# Altium schematic sheet Placa_extrusora.SchDoc(Placa_extrusora)
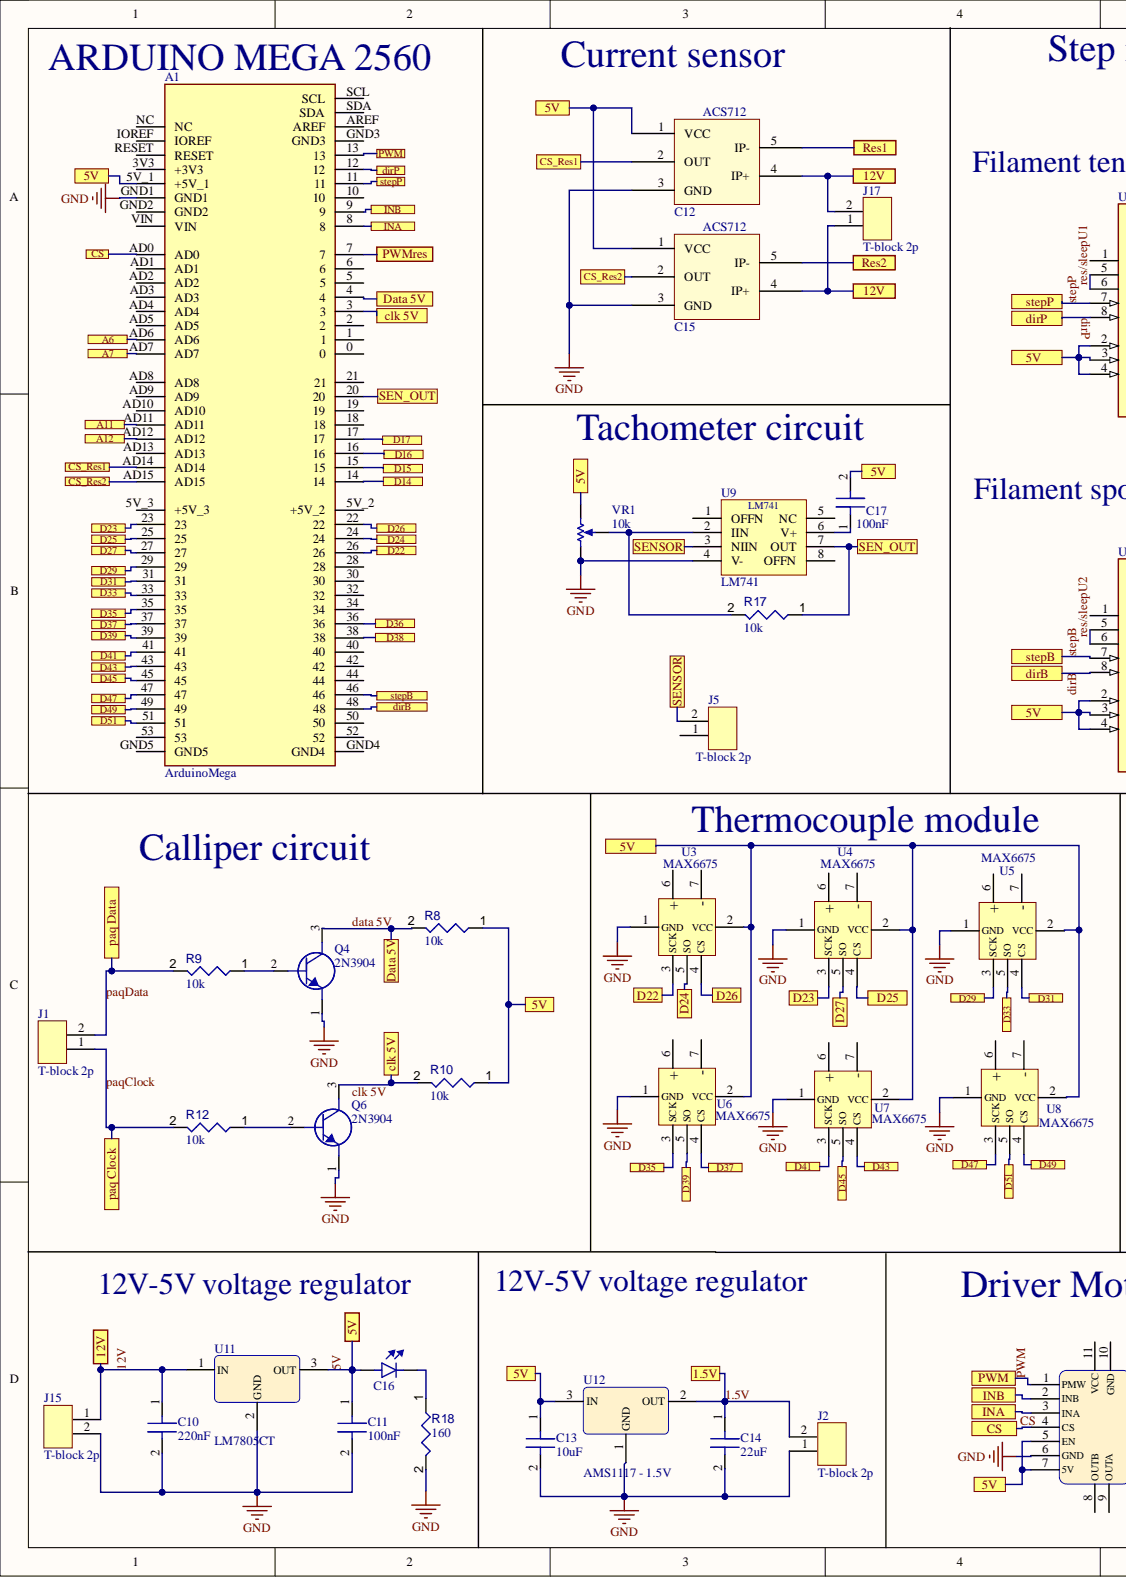
[diagram p0: Placa_extrusora.SchDoc, left]
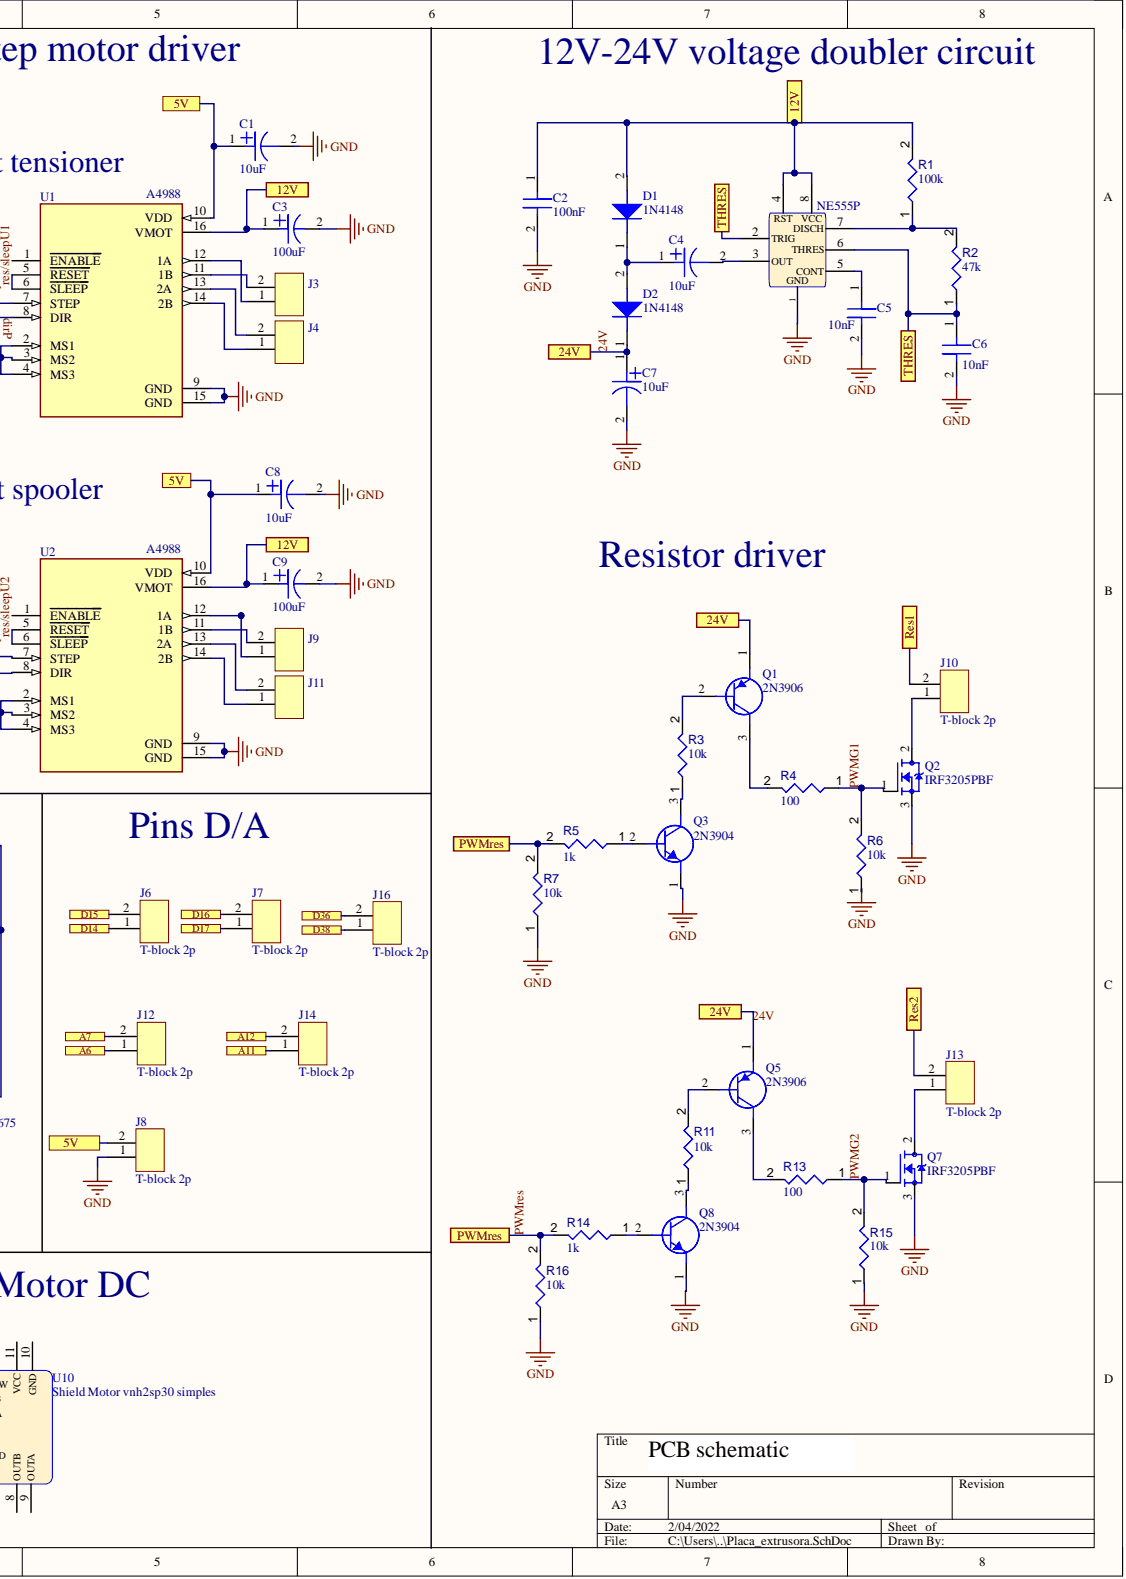
[diagram p1: Placa_extrusora.SchDoc, right]

## Parts
*  NE555P  [NE555P]
A1  ArduinoMega  [AmegaHeaders]
C1  10uF  [ElerolitcCap 35V]
C2  100nF  [CeramicCap 50V]
C3  100uF  [SMD CAP]
C4  10uF  [ElerolitcCap 35V]
C5  10nF  [CeramicCap 50V]
C6  10nF  [CeramicCap 50V]
C7  10uF  [ElerolitcCap 35V]
C8  10uF  [ElerolitcCap 35V]
C9  100uF  [SMD CAP]
C10  220nF  [CeramicCap 50V]
C11  100nF  [CeramicCap 50V]
C12  CMP-00001-1  [Current Sensor Module ACS712-Footprint-1]  (value not drawn)
C13  10uF  [CeramicCap 50V]
C14  22uF  [CeramicCap 50V]
C15  CMP-00001-1  [Current Sensor Module ACS712-Footprint-1]  (value not drawn)
C16  CMP-00006-2  [LED 5mm-Footprint-1]  (value not drawn)
C17  100nF  [CeramicCap 50V]
D1  1N4148  [1n4148 th]
D2  1N4148  [1n4148 th]
J1  T-block 2p  [T-block 2p]
J2  T-block 2p  [T-block 2p]
J3  T-block 2p  [T-block 2p]
J4  T-block 2p  [T-block 2p]
J5  T-block 2p  [T-block 2p]
J6  T-block 2p  [T-block 2p]
J7  T-block 2p  [T-block 2p]
J8  T-block 2p  [T-block 2p]
J9  T-block 2p  [T-block 2p]
J10  T-block 2p  [T-block 2p]
J11  T-block 2p  [T-block 2p]
J12  T-block 2p  [T-block 2p]
J13  T-block 2p  [T-block 2p]
J14  T-block 2p  [T-block 2p]
J15  T-block 2p  [T-block 2p]
J16  T-block 2p  [T-block 2p]
J17  T-block 2p  [T-block 2p]
Q1  2N3906  [2N3906]
Q2  IRF3205PBF  [IRF3205]
Q3  2N3904  [2N3904]
Q4  2N3904  [2N3904]
Q5  2N3906  [2N3906]
Q6  2N3904  [2N3904]
Q7  IRF3205PBF  [IRF3205]
Q8  2N3904  [2N3904]
R1  100k  [RESA60-630X240]
R2  47k  [RESA60-630X240]
R3  10k  [RESA60-630X240]
R4  100  [RESA60-630X240]
R5  1k  [RESA60-630X240]
R6  10k  [RESA60-630X240]
R7  10k  [RESA60-630X240]
R8  10k  [RESA60-630X240]
R9  10k  [RESA60-630X240]
R10  10k  [RESA60-630X240]
R11  10k  [RESA60-630X240]
R12  10k  [RESA60-630X240]
R13  100  [RESA60-630X240]
R14  1k  [RESA60-630X240]
R15  10k  [RESA60-630X240]
R16  10k  [RESA60-630X240]
R17  10k  [RESA60-630X240]
R18  value 160  [RESA60-630X240]  (hidden comment: 10k)
U1  A4988  [MODULE_A4988_STEPPER_MOTOR_DRIVER_CARRIER]
U2  A4988  [MODULE_A4988_STEPPER_MOTOR_DRIVER_CARRIER]
U3  MAX6675  [Max6675]
U4  MAX6675  [Max6675]
U5  MAX6675  [Max6675]
U6  MAX6675  [Max6675]
U7  MAX6675  [Max6675]
U8  MAX6675  [Max6675]
U9  LM741  [LM741 DIP]
U10  Shield Motor vnh2sp30 simples  [Shield monster simples VNH2SP30]
U11  LM7805CT  Output Voltage: 5V  [L78XX FAMILY]
U12  AMS1117 - 1.5V  [AMS1117]
VR1  Resistance: 10k  [BOUR-3362P]  (hidden comment: 3362P-1-103LF)

## Ports
1.5V, 5V, 12V, 24V, A6, A7, A11, A12, clk 5V, CS, CS_Res1, CS_Res2, D14, D15, D16, D17, D22, D23, D24, D25, D26, D27, D29, D31, D33, D35, D36, D37, D38, D39, D41, D43, D45, D47, D49, D51, Data 5V, dirB, dirP, INA, INB, paq Clock, paq Data, PWM, PWMres, Res1, Res2, SEN_OUT, SENSOR, stepB, stepP, THRES

## Nets
GND: *.1 A1.GND1 C1.2 C2.2 C3.2 C5.2 C6.2 C7.2 C8.2 C9.2 C10.2 C11.2 C12.3(GND) C13.2 C14.2 C15.3(GND) J2.1 J8.1 J15.2 Q2.3 Q3.1 Q4.1 Q6.1 Q7.3 Q8.1 R6.1 R7.1 R15.1 R16.1 R18.2 U1.9(GND) U1.15(GND) U2.9(GND) U2.15(GND) U3.1(GND) U4.1(GND) U5.1(GND) U6.1(GND) U7.1(GND) U8.1(GND) U9.4(V-) U10.6(GND) U11.2 U12.1 VR1.1
1.5V: C14.1 J2.2 U12.2 ; port 1.5V
5V: A1.5V_1(+5V_1) C1.1 C8.1 C11.1 C12.1(VCC) C13.1 C15.1(VCC) C16.1 C17.2 J8.2 R8.1 R10.1 U1.2(MS1) U1.3(MS2) U1.4(MS3) U1.10(VDD) U2.2(MS1) U2.3(MS2) U2.4(MS3) U2.10(VDD) U3.2(VCC) U4.2(VCC) U5.2(VCC) U6.2(VCC) U7.2(VCC) U8.2(VCC) U10.5(EN) U10.7(5V) U11.3 U12.3 VR1.3 ; port 5V
12V: *.4 *.8 C2.1 C3.1 C9.1 C10.1 C12.4(IP+) C15.4(IP+) D1.2 J15.1 J17.1 J17.2 R1.2 U1.16(VMOT) U2.16(VMOT) U11.1 ; port 12V
24V: C7.1 D2.1 Q1.1 Q5.1 ; port 24V
A6: A1.AD6 J12.1 ; port A6
A7: A1.AD7 J12.2 ; port A7
A11: A1.AD11 J14.1 ; port A11
A12: A1.AD12 J14.2 ; port A12
clk 5V: A1.3 Q6.3 R10.2 ; port clk 5V
CS: A1.AD0 U10.4(CS) ; port CS
CS_Res1: A1.AD14 C12.2(OUT) ; port CS_Res1
CS_Res2: A1.AD15 C15.2(OUT) ; port CS_Res2
D14: A1.14 J6.1 ; port D14
D15: A1.15 J6.2 ; port D15
D16: A1.16 J7.2 ; port D16
D17: A1.17 J7.1 ; port D17
D22: A1.26 U3.3(SCK) ; port D22
D23: A1.23 U4.3(SCK) ; port D23
D24: A1.24 U3.5(SO) ; port D24
D25: A1.25 U4.4(CS) ; port D25
D26: A1.22 U3.4(CS) ; port D26
D27: A1.27 U4.5(SO) ; port D27
D29: A1.29 U5.3(SCK) ; port D29
D31: A1.31 U5.4(CS) ; port D31
D33: A1.33 U5.5(SO) ; port D33
D35: A1.35 U6.3(SCK) ; port D35
D36: A1.36 J16.2 ; port D36
D37: A1.37 U6.4(CS) ; port D37
D38: A1.38 J16.1 ; port D38
D39: A1.39 U6.5(SO) ; port D39
D41: A1.41 U7.3(SCK) ; port D41
D43: A1.43 U7.4(CS) ; port D43
D45: A1.45 U7.5(SO) ; port D45
D47: A1.47 U8.3(SCK) ; port D47
D49: A1.49 U8.4(CS) ; port D49
D51: A1.51 U8.5(SO) ; port D51
data 5V: A1.4 Q4.3 R8.2 ; port Data 5V
dirB: A1.48 U2.8(DIR) ; port dirB
dirP: A1.12 U1.8(DIR) ; port dirP
INA: A1.8 U10.3(INA) ; port INA
INB: A1.9 U10.2(INB) ; port INB
paqClock: J1.1 R12.2 ; port paq Clock
paqData: J1.2 R9.2 ; port paq Data
PWM: A1.13 U10.1(PMW) ; port PWM
PWMG1: Q2.1 R4.1 R6.2
PWMres: A1.7 R5.2 R7.2 R14.2 R16.2 ; port PWMres
Res1: C12.5(IP-) J10.2 ; port Res1
Res2: C15.5(IP-) J13.2 ; port Res2
res/sleepU2: U2.5(RESET) U2.6(SLEEP)
SEN_OUT: A1.20 R17.1 U9.7(OUT) ; port SEN_OUT
SENSOR: J5.2 U9.3(NIIN) ; port SENSOR
stepB: A1.46 U2.7(STEP) ; port stepB
stepP: A1.11 U1.7(STEP) ; port stepP
THRES: *.2(TRIG) *.6(THRES) C6.1 R2.1 ; port THRES
Net*_3: *.3(OUT) C4.2
Net*_5: *.5(CONT) C5.1
Net*_7: *.7(DISCH) R1.1 R2.2
NetC4_1: C4.1 D1.1 D2.2
NetC16_2: C16.2 R18.1
NetC17_1: C17.1 U9.6(V+)
NetJ3_1: J3.1 U1.12(1A)
NetJ3_2: J3.2 U1.11(1B)
NetJ4_1: J4.1 U1.14(2B)
NetJ4_2: J4.2 U1.13(2A)
NetJ9_1: J9.1 U2.12(1A)
NetJ9_2: J9.2 U2.11(1B)
NetJ10_1: J10.1 Q2.2
NetJ11_1: J11.1 U2.14(2B)
NetJ11_2: J11.2 U2.13(2A)
NetJ13_1: J13.1 Q7.2
NetQ1_2: Q1.2 R3.2
NetQ1_3: Q1.3 R4.2
NetQ3_2: Q3.2 R5.1
NetQ3_3: Q3.3 R3.1
NetQ4_2: Q4.2 R9.1
NetQ5_2: Q5.2 R11.2
NetQ5_3: Q5.3 R13.2
NetQ6_2: Q6.2 R12.1
NetQ7_1: Q7.1 R13.1 R15.2
NetQ8_2: Q8.2 R14.1
NetQ8_3: Q8.3 R11.1
NetR17_2: R17.2 U9.2(IIN) VR1.2
NetU1_5: U1.5(RESET) U1.6(SLEEP)
5V:
PWMG2:
PWMres:
res/sleepU1:

Not connected: A1 0 1 2 3V3(+3V3) 5 5V_2(+5V_2) 5V_3(+5V_3) 6 10 18 19 21 28 30 32 34 40 42 44 50 52 53 AD1 AD2 AD3 AD4 AD5 AD8 AD9 AD10 AD13 AREF GND2 GND3 GND4 GND5 IOREF NC RESET SCL SDA VIN; J5 1; U1 1(ENABLE); U2 1(ENABLE); U3 6(+) 7(-); U4 6(+) 7(-); U5 6(+) 7(-); U6 6(+) 7(-); U7 6(+) 7(-); U8 6(+) 7(-); U9 1(OFFN) 5(NC) 8(OFFN); U10 8(OUTB) 9(OUTA) 10(GND) 11(VCC)

## Library data of the parts
* = NE555P: Standard Timer Single 8-Pin PDIP Tube; Manufacturer: Texas Instruments; Current Rating: 10mA; Frequency: 500kHz; High Level Output Current: -200mA; Low Level Output Current: 200mA; Max Supply Voltage: 16V; Min Supply Voltage: 4.5V; Nominal Supply Current: 2mA; Number of Timers/Counters: 1; Operating Supply Voltage: 5V; Quiescent Current: 15mA; Case/Package: PDIP; Category: Clock and Timing; Component Kind: Standard; ComponentLink1Description: https://datasheet.ciiva.com/1139/sa555-1139904.pdf?src-supplier=Element14; ComponentLink1URL: https://datasheet.ciiva.com/1139/sa555-1139904.pdf?src-supplier=Element14; ComponentLink2Description: https://datasheet.ciiva.com/26325/152184-da-01-en-ne555p-timer-tid-26325915.pdf?src-supplier=Conrad; ComponentLink2URL: https://datasheet.ciiva.com/26325/152184-da-01-en-ne555p-timer-tid-26325915.pdf?src-supplier=Conrad; ComponentLink3Description: https://datasheet.ciiva.com/14274/ne555-14274456.pdf?src-supplier=Avnet; ComponentLink3URL: https://datasheet.ciiva.com/14274/ne555-14274456.pdf?src-supplier=Avnet; ComponentLink4Description: https://datasheet.ciiva.com/1233/ne555-1233454.pdf?src-supplier=Digi-Key; ComponentLink4URL: https://datasheet.ciiva.com/1233/ne555-1233454.pdf?src-supplier=Digi-Key; ComponentLink5Description: https://datasheet.ciiva.com/14094/ne555-series-eng-tds-14094950.pdf?src-supplier=Distrelec; ComponentLink5URL: https://datasheet.ciiva.com/14094/ne555-series-eng-tds-14094950.pdf?src-supplier=Distrelec; ComponentLink6Description: https://datasheet.ciiva.com/15152/getdatasheetpartid-248510-15152620.pdf?src-supplier=Verical; ComponentLink6URL: https://datasheet.ciiva.com/15152/getdatasheetpartid-248510-15152620.pdf?src-supplier=Verical; ComponentLink7Description: https://datasheet.ciiva.com/1147/1764032-1147750.pdf?src-supplier=Newark; ComponentLink7URL: https://datasheet.ciiva.com/1147/1764032-1147750.pdf?src-supplier=Newark; ComponentLink8Description: https://datasheet.ciiva.com/26228/ne555-26228892.pdf?src-supplier=Avnet+Europe; ComponentLink8URL: https://datasheet.ciiva.com/26228/ne555-26228892.pdf?src-supplier=Avnet+Europe; Contact Plating: Gold; Height: 4.57mm; Lead Free: Lead Free; Length: 9.81mm; Manufacturer Part Number: NE555P; Max Operating Temperature: 70°C; Min Operating Temperature: 0°C; Mount: Through Hole; Number of Pins: 8; Operating Supply Current: 10mA; Package Quantity: 50; Packaging: Rail/Tube; Pricing 1: 1=0,37000, 10=0,29900, 25=0,27360, 100=0,20350, 250=0,18444, 500=0,15264, 1000=0,11448, 2500=0,10494, 5000=0,10020 (USD); Radiation Hardening: No; REACH SVHC: No SVHC; RoHS: Yes; RoHS Compliant: Yes; Stock 1: 3513; Supplier 1: Digi-Key; Supplier Part Number 1: 296-NE555P-ND; Weight: 0.015535oz; Width: 6.35mm; Library Name: Extrusora_Schlib.SchLib
A1 = ArduinoMega: Arduino mega com headers macho acoplados; Component Kind: Standard; Library Name: Extrusora_Schlib.SchLib
C1 C4 C7 C8 = 10uF SMD Eletrolitc Cap: CAP ALUM 10UF 20% 35V SMD; Manufacturer: Panasonic; Manufacturer Part Number: EEEFK1V100UR; ESR (Equivalent Series Resistance): 1.35R; Leakage Current: 3uA; Life (Hours): 2000Hour; Material: Aluminium; Polarity: Polar; Ripple Current: 90mA; Capacitance: 10uF; Case/Package: Radial; Category: Aluminum Electrolytic Capacitors; Component Kind: Standard; ComponentLink1Description: https://datasheet.ciiva.com/11777/0900766b80e4e8b0-11777251.pdf?src-supplier=RSComponents; ComponentLink1URL: https://datasheet.ciiva.com/11777/0900766b80e4e8b0-11777251.pdf?src-supplier=RSComponents; ComponentLink2Description: https://datasheet.ciiva.com/29313/443474-da-01-en-smd-elko-vfk-b-10-uf-35v-29313572.pdf?src-supplier=Conrad; ComponentLink2URL: https://datasheet.ciiva.com/29313/443474-da-01-en-smd-elko-vfk-b-10-uf-35v-29313572.pdf?src-supplier=Conrad; ComponentLink3Description: https://datasheet.ciiva.com/3773/41790907s9016630n1386-3773674.pdf?src-supplier=Arrow+Electronics; ComponentLink3URL: https://datasheet.ciiva.com/3773/41790907s9016630n1386-3773674.pdf?src-supplier=Arrow+Electronics; ComponentLink4Description: https://datasheet.ciiva.com/11673/aba0000ce120-11673880.pdf?src-supplier=Avnet; ComponentLink4URL: https://datasheet.ciiva.com/11673/aba0000ce120-11673880.pdf?src-supplier=Avnet; ComponentLink5Description: https://datasheet.ciiva.com/2983/aba0000ce120-2983969.pdf?src-supplier=Avnet; ComponentLink5URL: https://datasheet.ciiva.com/2983/aba0000ce120-2983969.pdf?src-supplier=Avnet; ComponentLink6Description: https://datasheet.ciiva.com/43463/58258-43463543.pdf?src-supplier=Farnell; ComponentLink6URL: https://datasheet.ciiva.com/43463/58258-43463543.pdf?src-supplier=Farnell; ComponentLink7Description: https://datasheet.ciiva.com/43485/1676921-43485502.pdf?src-supplier=Farnell; ComponentLink7URL: https://datasheet.ciiva.com/43485/1676921-43485502.pdf?src-supplier=Farnell; ComponentLink8Description: https://datasheet.ciiva.com/30131/aba0000ce120-30131022.pdf?src-supplier=Onlinecomponents.com; ComponentLink8URL: https://datasheet.ciiva.com/30131/aba0000ce120-30131022.pdf?src-supplier=Onlinecomponents.com; ComponentLink9Description: https://datasheet.ciiva.com/48524/fk-eng-tds-48524122.pdf?src-supplier=Distrelec; ComponentLink9URL: https://datasheet.ciiva.com/48524/fk-eng-tds-48524122.pdf?src-supplier=Distrelec; ComponentLink10Description: https://datasheet.ciiva.com/46437/1676921-46437695.pdf?src-supplier=Element14; ComponentLink10URL: https://datasheet.ciiva.com/46437/1676921-46437695.pdf?src-supplier=Element14; ComponentLink11Description: https://datasheet.ciiva.com/17356/aba0000ce120-17356246.pdf?src-supplier=Avnet+Europe; ComponentLink11URL: https://datasheet.ciiva.com/17356/aba0000ce120-17356246.pdf?src-supplier=Avnet+Europe; Construction: Cylindrical; Contact Plating: Tin; Diameter: 4mm; Height: 5.8mm; Height - Seated (Max): 0.24inch; Impedance: 1.35R; Lead Free: Lead Free; Length: 5.8mm; Max Operating Temperature: 105°C; Min Operating Temperature: -55°C; Mount: Surface Mount,PCB; Number of Pins: 2; Package Quantity: 1; Packaging: Tape and Reel; Pricing 1: 1=0,35000, 10=0,24000, 50=0,16820, 100=0,14420, 500=0,10816, 1000=0,09133 (USD); Radiation Hardening: No; REACH SVHC: No SVHC; Ripple Current (AC): 90mA; RoHS: Yes; RoHS Compliant: Yes; Series: FK; Stock 1: 7253; Supplier 1: Digi-Key; Supplier Part Number 1: PCE3834CT-ND; Termination: SMD/SMT; Tolerance: 20%; Voltage: 35V; Voltage Rating: 35V; Voltage Rating (DC): 35V; Width: 5.5mm; Library Name: Extrusora_Schlib.SchLib
C2 C11 = 100nF CAP TH: CAP CER 0.1UF 50V X7R RADIAL; Manufacturer: Murata; Manufacturer Part Number: RDER71H104K0M1H03A; Lead Diameter: 0.5mm; Lead/Base Style: Kinked; Tolerance: 10%; Capacitance: 100nF; Case/Package: Radial; Category: Ceramic Capacitors; Component Kind: Standard; ComponentLink1Description: https://datasheet.ciiva.com/29534/rdeseries-29534878.pdf?src-supplier=Digi-Key; ComponentLink1URL: https://datasheet.ciiva.com/29534/rdeseries-29534878.pdf?src-supplier=Digi-Key; ComponentLink2Description: https://datasheet.ciiva.com/29534/rdeseries-29534879.pdf?src-supplier=Digi-Key; ComponentLink2URL: https://datasheet.ciiva.com/29534/rdeseries-29534879.pdf?src-supplier=Digi-Key; Dielectric: X7R; Height: 3.5mm; Height - Seated (Max): 0.236inch; Lead Free: Lead Free; Lead Pitch: 0.197inch; Length: 0.157inch; Max Operating Temperature: 125°C; Min Operating Temperature: -55°C; Mount: Through Hole; Package Quantity: 2000; Packaging: Tape and Reel; Pricing 1: 1=0,34000, 10=0,23800, 50=0,16640, 100=0,14260, 500=0,10692, 1000=0,09029 (USD); Resistor Type: Ceramic; RoHS: Yes; RoHS Compliant: Yes; Stock 1: 11539; Supplier 1: Digi-Key; Supplier Part Number 1: 490-9144-1-ND; Termination: Radial; Voltage Rating: 50V; Voltage Rating (DC): 50V; Width: 3.5mm; Library Name: Extrusora_Schlib.SchLib
C3 C9 = 100uF SMD Eletrolitc CAP: Cap Aluminum 100uF 35V 20% (8 X 10mm) SMD 283mA 2000 hr 105C T/R; Manufacturer: Nichicon; Manufacturer Part Number: UUX1V101MNL6GS; Leakage Current: 105uA; Life (Hours): 2000Hour; Capacitance: 100uF; Case/Package: Radial; Category: Aluminum Electrolytic Capacitors; Component Kind: Standard; ComponentLink1Description: https://datasheet.ciiva.com/6298/e-ux-6298558.pdf?src-supplier=Digi-Key; ComponentLink1URL: https://datasheet.ciiva.com/6298/e-ux-6298558.pdf?src-supplier=Digi-Key; ComponentLink2Description: https://datasheet.ciiva.com/11685/e-ux-11685958.pdf?src-supplier=Avnet; ComponentLink2URL: https://datasheet.ciiva.com/11685/e-ux-11685958.pdf?src-supplier=Avnet; ComponentLink3Description: https://datasheet.ciiva.com/17525/e-ux-17525454.pdf?src-supplier=Avnet+Europe; ComponentLink3URL: https://datasheet.ciiva.com/17525/e-ux-17525454.pdf?src-supplier=Avnet+Europe; Construction: Cylindrical; Diameter: 8mm; Features: General Purpose; Height: 10mm; Height - Seated (Max): 0.413inch; Length: 10mm; Max Operating Temperature: 105°C; Min Operating Temperature: -55°C; Mount: Surface Mount; Package Quantity: 1; Packaging: Tape and Reel; Pricing 1: 1=0,68000, 10=0,48300, 50=0,36500, 100=0,31800 (USD); Radiation Hardening: No; Ripple Current: 283mA; RoHS: Yes; RoHS Compliant: Yes; Series: UX; Stock 1: 978; Supplier 1: Digi-Key; Supplier Part Number 1: 493-6276-1-ND; Termination: SMD/SMT; Tolerance: 20%; Voltage Rating: 35V; Voltage Rating (DC): 35V; Library Name: Extrusora_Schlib.SchLib
C5 C6 = 10nF: Multilayer Ceramic Capacitors MLCC - Leaded 0.01uF 50volts X7R LS=5mm +/-10%; Manufacturer: Murata; Manufacturer Part Number: RCER71H103K0K1H03B; Lead Diameter: 0.5mm; Lead/Base Style: Formed Leads; Tolerance: 10%; Voltage Rating: 50V; Capacitance: 10nF; Case/Package: Radial; Category: Ceramic Capacitors; Component Kind: Standard; ComponentLink1Description: https://datasheet.ciiva.com/18699/product-18699570.pdf?src-supplier=Digi-Key; ComponentLink1URL: https://datasheet.ciiva.com/18699/product-18699570.pdf?src-supplier=Digi-Key; Dielectric: X7R; Height: 3.5mm; Height - Seated (Max): 0.138inch; Lead Free: Lead Free; Lead Pitch: 0.197inch; Length: 0.142inch; Max Operating Temperature: 125°C; Min Operating Temperature: -55°C; Mount: Through Hole; Package Quantity: 500; Packaging: Bulk; Pricing 1: 1=0,47000, 10=0,32400, 50=0,22680, 100=0,19440, 500=0,14580, 1000=0,12312, 2500=0,11664, 5000=0,11016 (USD); Ratings: AEC-Q200; Resistor Type: Ceramic; RoHS: Yes; RoHS Compliant: Yes; Stock 1: 227; Supplier 1: Digi-Key; Supplier Part Number 1: 490-11884-ND; Termination: Radial; Voltage Rating (DC): 50V; Width: 0.098inch; Library Name: Extrusora_Schlib.SchLib
C10 = 100nF CAP TH: CAP TAN 220nF 35V RADIAL; Manufacturer: Murata; Manufacturer Part Number: RDER71H104K0M1H03A; Capacitance: 100nF; Dielectric: X7R; Lead Diameter: 0.5mm; Lead/Base Style: Kinked; Tolerance: 10%; Voltage Rating: 50V; Case/Package: Radial; Category: Ceramic Capacitors; Component Kind: Standard; ComponentLink1Description: https://datasheet.ciiva.com/29534/rdeseries-29534878.pdf?src-supplier=Digi-Key; ComponentLink1URL: https://datasheet.ciiva.com/29534/rdeseries-29534878.pdf?src-supplier=Digi-Key; ComponentLink2Description: https://datasheet.ciiva.com/29534/rdeseries-29534879.pdf?src-supplier=Digi-Key; ComponentLink2URL: https://datasheet.ciiva.com/29534/rdeseries-29534879.pdf?src-supplier=Digi-Key; Height: 3.5mm; Height - Seated (Max): 0.236inch; Lead Free: Lead Free; Lead Pitch: 0.197inch; Length: 0.157inch; Max Operating Temperature: 125°C; Min Operating Temperature: -55°C; Mount: Through Hole; Package Quantity: 2000; Packaging: Tape and Reel; Pricing 1: 1=0,34000, 10=0,23800, 50=0,16640, 100=0,14260, 500=0,10692, 1000=0,09029 (USD); Resistor Type: Ceramic; RoHS: Yes; RoHS Compliant: Yes; Stock 1: 11539; Supplier 1: Digi-Key; Supplier Part Number 1: 490-9144-1-ND; Termination: Radial; Voltage Rating (DC): 50V; Width: 3.5mm; Library Name: Extrusora_Schlib.SchLib
C12 C15 = CMP-012-000001-3: Current Sensor with ACS712 IC; Component Kind: Standard; Component Type: Standard; Design Item ID: CMP-00001-1; Pin Count: 5; Library Name: Universidade Estadual Paulista - Unesp; Source: Sistema_Elevador_ElevaUV.SCHLIB
C13 C14 = 100nF CAP TH: CAP CER 0.1UF 50V X7R RADIAL; Manufacturer: Murata; Manufacturer Part Number: RDER71H104K0M1H03A; Capacitance: 100nF; Lead Diameter: 0.5mm; Lead/Base Style: Kinked; Tolerance: 10%; Case/Package: Radial; Category: Ceramic Capacitors; Component Kind: Standard; ComponentLink1Description: https://datasheet.ciiva.com/29534/rdeseries-29534878.pdf?src-supplier=Digi-Key; ComponentLink1URL: https://datasheet.ciiva.com/29534/rdeseries-29534878.pdf?src-supplier=Digi-Key; ComponentLink2Description: https://datasheet.ciiva.com/29534/rdeseries-29534879.pdf?src-supplier=Digi-Key; ComponentLink2URL: https://datasheet.ciiva.com/29534/rdeseries-29534879.pdf?src-supplier=Digi-Key; Dielectric: X7R; Height: 3.5mm; Height - Seated (Max): 0.236inch; Lead Free: Lead Free; Lead Pitch: 0.197inch; Length: 0.157inch; Max Operating Temperature: 125°C; Min Operating Temperature: -55°C; Mount: Through Hole; Package Quantity: 2000; Packaging: Tape and Reel; Pricing 1: 1=0,34000, 10=0,23800, 50=0,16640, 100=0,14260, 500=0,10692, 1000=0,09029 (USD); Resistor Type: Ceramic; RoHS: Yes; RoHS Compliant: Yes; Stock 1: 11539; Supplier 1: Digi-Key; Supplier Part Number 1: 490-9144-1-ND; Termination: Radial; Voltage Rating: 50V; Voltage Rating (DC): 50V; Width: 3.5mm; Library Name: Extrusora_Schlib.SchLib
C16 = CMP-012-000005-1: LED 5mm; Component Kind: Standard; Component Type: Standard; Design Item ID: CMP-00006-2; Pin Count: 2; Library Name: Universidade Estadual Paulista - Unesp; Source: Sistema_Elevador_ElevaUV.SCHLIB
C17 = CMP-003-000001-1: CAP CER 0.1UF 50V X7R RADIAL; Manufacturer: Murata; Manufacturer Part Number: RDER71H104K0M1H03A; Lead Diameter: 0.5mm; Lead/Base Style: Kinked; Tolerance: 10%; Capacitance: 100nF; Case/Package: Radial; Category: Ceramic Capacitors; Component Kind: Standard; ComponentLink1Description: https://datasheet.ciiva.com/29534/rdeseries-29534878.pdf?src-supplier=Digi-Key; ComponentLink1URL: https://datasheet.ciiva.com/29534/rdeseries-29534878.pdf?src-supplier=Digi-Key; ComponentLink2Description: https://datasheet.ciiva.com/29534/rdeseries-29534879.pdf?src-supplier=Digi-Key; ComponentLink2URL: https://datasheet.ciiva.com/29534/rdeseries-29534879.pdf?src-supplier=Digi-Key; Design Item ID: 100nF CAP TH; Dielectric: X7R; Height: 3.5mm; Height - Seated (Max): 0.236inch; Lead Free: Lead Free; Lead Pitch: 0.197inch; Length: 0.157inch; Max Operating Temperature: 125°C; Min Operating Temperature: -55°C; Mount: Through Hole; Package Quantity: 2000; Packaging: Tape and Reel; Pricing 1: 1=0,34000, 10=0,23800, 50=0,16640, 100=0,14260, 500=0,10692, 1000=0,09029 (USD); Resistor Type: Ceramic; RoHS: Yes; RoHS Compliant: Yes; Stock 1: 11539; Termination: Radial; Voltage Rating: 50V; Voltage Rating (DC): 50V; Width: 3.5mm; Library Name: Universidade Estadual Paulista - Unesp; Source: Extrusora_Schlib.SchLib
D1 D2 = 1N4148-TAP: DIODE SWITCHING 75V 0.3A DO35; Manufacturer: Vishay Semiconductors; Average Rectified Current: 300mA; Element Configuration: Single; Forward Voltage: 1V; Max Forward Surge Current (Ifsm): 2A; Max Power Dissipation: 500mW; Max Repetitive Reverse Voltage (Vrrm): 100V; Max Reverse Leakage Current: 5uA; Recovery Time: 8ns; Reverse Recovery Time-Max: 4ns; Case/Package: DO-35; Category: Rectifier Diodes; Component Kind: Standard; ComponentLink1Description: https://datasheet.ciiva.com/4434/1n4148-97398-4434784.pdf?src-supplier=Mouser; ComponentLink1URL: https://datasheet.ciiva.com/4434/1n4148-97398-4434784.pdf?src-supplier=Mouser; ComponentLink2Description: https://datasheet.ciiva.com/29593/1n4148-29593104.pdf?src-supplier=Digi-Key; ComponentLink2URL: https://datasheet.ciiva.com/29593/1n4148-29593104.pdf?src-supplier=Digi-Key; ComponentLink3Description: https://datasheet.ciiva.com/15190/getdatasheetpartid-317463-15190416.pdf?src-supplier=Verical; ComponentLink3URL: https://datasheet.ciiva.com/15190/getdatasheetpartid-317463-15190416.pdf?src-supplier=Verical; ComponentLink4Description: https://datasheet.ciiva.com/1242/1748092-1242237.pdf?src-supplier=Newark; ComponentLink4URL: https://datasheet.ciiva.com/1242/1748092-1242237.pdf?src-supplier=Newark; ComponentLink5Description: https://datasheet.ciiva.com/3304/1n4148-3304220.pdf?src-supplier=Avnet; ComponentLink5URL: https://datasheet.ciiva.com/3304/1n4148-3304220.pdf?src-supplier=Avnet; ComponentLink6Description: https://datasheet.ciiva.com/25919/1n4148-25919597.pdf?src-supplier=Avnet+Europe; ComponentLink6URL: https://datasheet.ciiva.com/25919/1n4148-25919597.pdf?src-supplier=Avnet+Europe; ComponentLink7Description: https://datasheet.ciiva.com/16312/1n4148-16312118.pdf?src-supplier=Future+Electronics; ComponentLink7URL: https://datasheet.ciiva.com/16312/1n4148-16312118.pdf?src-supplier=Future+Electronics; Diameter: 1.75mm; Forward Current: 300mA; Height: 1.7mm; Lead Free: Lead Free; Length: 3.4mm; Manufacturer Part Number: 1N4148-TAP; Max Operating Temperature: 175°C; Max Reverse Voltage (DC): 75V; Max Surge Current: 2A; Min Operating Temperature: -65°C; Mount: Through Hole; Number of Pins: 2; Package Quantity: 1; Packaging: Ammo Pack; Peak Non-Repetitive Surge Current: 2A; Power Dissipation: 500mW; Pricing 1: 1=0,10000, 10=0,09500, 100=0,05190, 500=0,03194, 1000=0,02178, 2000=0,01852, 5000=0,01670 (USD); Radiation Hardening: No; REACH SVHC: Unknown; Reverse Recovery Time: 8ns; RoHS: Yes; RoHS Compliant: Yes; Series: -; Stock 1: 778; Supplier 1: Digi-Key; Supplier Part Number 1: 1N4148-TAPCT-ND; Voltage: 75V; Width: 1.7mm; Library Name: Extrusora_Schlib.SchLib
J1-J4 J6-J17 = T-block 2p: WURTH ELEKTRONIK - 691213710002 - Wire-To-Board Terminal Block, 2, 300 V, 10 A, 26 AWG, 16 AWG, 1.5 mm RoHS Compliant: Yes; Manufacturer: Wurth Electronics; Current: 10A; Number of Contacts: 2; Number of Levels: 1; Pitch: 0.197inch; Plating: Brass,Steel; Stripping Length: -7mm; Voltage: 300V; Wire Gauge (Max): 26AWG; Wire Gauge (Min): 16AWG; Category: Terminal Blocks; Color: Green; Component Kind: Standard; ComponentLink1Description: https://datasheet.ciiva.com/824/6912137100xx-824076.pdf?src-supplier=Digi-Key; ComponentLink1URL: https://datasheet.ciiva.com/824/6912137100xx-824076.pdf?src-supplier=Digi-Key; ComponentLink2Description: https://datasheet.ciiva.com/48693/001088616-da-01-en-wr-tbl-terminal-block213-691213710002-48693191.pdf?src-supplier=Conrad; ComponentLink2URL: https://datasheet.ciiva.com/48693/001088616-da-01-en-wr-tbl-terminal-block213-691213710002-48693191.pdf?src-supplier=Conrad; Contact Material: Brass; Contact Plating: Brass,Tin; Current Rating: 10A; Height: 10mm; Housing Material: PA66; Length: 8.3mm; Manufacturer Part Number: 691213710002; Max Operating Temperature: 105°C; Min Operating Temperature: -40°C; Mount: Through Hole; Number of Positions Per Level: 2; Package Quantity: 650; Packaging: Bulk; Pricing 1: 1=0,96000, 10=0,86200, 50=0,74760 (USD); REACH SVHC: Unknown; RoHS: Yes; RoHS Compliant: Yes; Series: WR-TBL Series 213; Stock 1: 1369; Supplier 1: Digi-Key; Termination: Screw; Voltage Rating: 300V; Width: 10.6mm; Wire/Cable Gauge: 26AWG; Library Name: Extrusora_Schlib.SchLib
J5 = CMP-009-000006-1: WURTH ELEKTRONIK - 691213710002 - Wire-To-Board Terminal Block, 2, 300 V, 10 A, 26 AWG, 16 AWG, 1.5 mm RoHS Compliant: Yes; Manufacturer: Wurth Electronics; Current: 10A; Number of Contacts: 2; Number of Levels: 1; Pitch: 0.197inch; Plating: Brass,Steel; Stripping Length: -7mm; Voltage: 300V; Wire Gauge (Max): 26AWG; Wire Gauge (Min): 16AWG; Category: Terminal Blocks; Color: Green; Component Kind: Standard; ComponentLink1Description: https://datasheet.ciiva.com/824/6912137100xx-824076.pdf?src-supplier=Digi-Key; ComponentLink1URL: https://datasheet.ciiva.com/824/6912137100xx-824076.pdf?src-supplier=Digi-Key; ComponentLink2Description: https://datasheet.ciiva.com/48693/001088616-da-01-en-wr-tbl-terminal-block213-691213710002-48693191.pdf?src-supplier=Conrad; ComponentLink2URL: https://datasheet.ciiva.com/48693/001088616-da-01-en-wr-tbl-terminal-block213-691213710002-48693191.pdf?src-supplier=Conrad; Contact Material: Brass; Contact Plating: Brass,Tin; Current Rating: 10A; Design Item ID: T-block 2p; Height: 10mm; Housing Material: PA66; Length: 8.3mm; Manufacturer Part Number: 691213710002; Max Operating Temperature: 105°C; Min Operating Temperature: -40°C; Mount: Through Hole; Number of Positions Per Level: 2; Package Quantity: 650; Packaging: Bulk; Pricing 1: 1=0,96000, 10=0,86200, 50=0,74760 (USD); REACH SVHC: Unknown; RoHS: Yes; RoHS Compliant: Yes; Series: WR-TBL Series 213; Stock 1: 1369; Termination: Screw; Voltage Rating: 300V; Width: 10.6mm; Wire/Cable Gauge: 26AWG; Library Name: Universidade Estadual Paulista - Unesp; Source: Extrusora_Schlib.SchLib
Q1 Q5 = 2N3906: TRANS PNP 40V 0.2A TO-92; Manufacturer: STMicroelectronics; Collector Base Voltage (VCBO): 60V; Collector-emitter Voltage-Max: 400mV; Current Rating: -200mA; Element Configuration: Single; Emitter Base Voltage (VEBO): -6V; Frequency: 250MHz; hFE Min: 30; Max Collector Current: 200mA; Max Power Dissipation: 625mW; Number of Elements: 1; Voltage Rating (DC): -60V; Case/Package: TO-92; Category: BJTs; Collector Emitter Breakdown Voltage: 40V; Collector Emitter Voltage (VCEO): 40V; Component Kind: Standard; ComponentLink1Description: https://datasheet.ciiva.com/16286/cd00002988-314324-16286532.pdf?src-supplier=Mouser; ComponentLink1URL: https://datasheet.ciiva.com/16286/cd00002988-314324-16286532.pdf?src-supplier=Mouser; ComponentLink2Description: https://datasheet.ciiva.com/985/cd00002988-985288.pdf?src-supplier=Digi-Key; ComponentLink2URL: https://datasheet.ciiva.com/985/cd00002988-985288.pdf?src-supplier=Digi-Key; Gain Bandwidth Product: 250MHz; Lead Free: Lead Free; Manufacturer Part Number: 2N3906; Max Operating Temperature: 150°C; Min Operating Temperature: -65°C; Mount: Through Hole; Number of Pins: 3; Package Quantity: 2500; Packaging: Bulk; Polarity: PNP; Power Dissipation: 625mW; Radiation Hardening: No; RoHS: Yes; RoHS Compliant: Yes; Series: -; Supplier 1: Digi-Key; Transition Frequency: 250MHz; Library Name: Extrusora_Schlib.SchLib
Q2 Q7 = IRF3205PBF: MOSFET, N, 55V, 98A, TO-220; Transistor Polarity:N Channel; Continuous Drain Current Id:98A; Drain Source Voltage Vds:55V; On Resistance Rds(on):8mohm; Rds(on) Test Voltage Vgs:10V; Threshold Voltage Vgs:4V; Power Dissipation Pd:150W; Transistor Case Style:TO-220AB; No. of Pins:3; Operating Temperature Max:175°C; MSL:-; SVHC:No SVHC (17-Dec-2015); Current Id Max:110A; Current Temperature:25°C; Full Power Rating Temperature:25°C; Junction to Case Thermal Resistance A:1°C/W; Lead Spacing:2.54mm; No. of Transistors:1; On State resistance @ Vgs = 10V:8mohm; Operating Temperature Min:-55°C; Pin For; Manufacturer: International Rectifier; Continuous Drain Current (ID): 110A; Drain to Source Resistance: 8mR; Element Configuration: Single; Fall Time: 65ns; Gate to Source Voltage (Vgs): 20V; Input Capacitance: 3.247nF; Max Power Dissipation: 200W; Nominal Vgs: 4V; Number of Elements: 1; Recovery Time: 104ns; Rise Time: 101ns; Turn-Off Delay Time: 50ns; Turn-On Delay Time: 14ns; Case/Package: TO-220AB; Category: MOSFETs; Component Kind: Standard; ComponentLink1Description: https://datasheet.ciiva.com/19459/1559640-19459508.pdf?src-supplier=Jameco; ComponentLink1URL: https://datasheet.ciiva.com/19459/1559640-19459508.pdf?src-supplier=Jameco; ComponentLink2Description: https://datasheet.ciiva.com/7459/irf3205-7459155.pdf?src-supplier=Newark; ComponentLink2URL: https://datasheet.ciiva.com/7459/irf3205-7459155.pdf?src-supplier=Newark; ComponentLink3Description: https://datasheet.ciiva.com/7835/irf3205-7835829.pdf?src-supplier=Element14; ComponentLink3URL: https://datasheet.ciiva.com/7835/irf3205-7835829.pdf?src-supplier=Element14; ComponentLink4Description: https://datasheet.ciiva.com/16199/irf3205-16199100.pdf?src-supplier=Future+Electronics; ComponentLink4URL: https://datasheet.ciiva.com/16199/irf3205-16199100.pdf?src-supplier=Future+Electronics; ComponentLink5Description: https://datasheet.ciiva.com/6368/irf3205-6368316.pdf?src-supplier=Digi-Key; ComponentLink5URL: https://datasheet.ciiva.com/6368/irf3205-6368316.pdf?src-supplier=Digi-Key; Current Rating: 110A; Drain to Source Breakdown Voltage: 55V; Drain to Source Voltage (Vdss): 55V; Dual Supply Voltage: 55V; Height: 8.77mm; Lead Free: Lead Free; Lead Pitch: 2.54mm; Length: 10.54mm; Manufacturer Part Number: IRF3205PBF; Max Operating Temperature: 175°C; Min Operating Temperature: -55°C; Mount: Through Hole; Number of Pins: 3; On-State Resistance: 8mR; Package Quantity: 50; Packaging: Rail/Tube; Power Dissipation: 200W; Pricing 1: 1=1,42000, 10=1,26600, 25=1,20160, 100=0,98710, 500=0,81544, 1000=0,65310 (USD); Radiation Hardening: No; Rds On Max: 8mR; REACH SVHC: No SVHC; RoHS: Yes; RoHS Compliant: Yes; Supplier 1: Digi-Key; Supplier Part Number 1: IRF3205PBF-ND; Termination: Through Hole; Threshold Voltage: 4V; Voltage Rating (DC): 55V; Width: 4.69mm; Library Name: Extrusora_Schlib.SchLib
Q3 Q4 Q6 Q8 = 2N3904: NTE ELECTRONICS - 2N3904 - RF TRANSISTOR, NPN, 40V, 250MHZ; Max Power Dissipation: 625mW; Case/Package: 200; Category: Transistors; Collector Emitter Breakdown Voltage: 40V; Collector Emitter Voltage (VCEO): 40V; Component Kind: Standard; ComponentLink1Description: https://datasheet.ciiva.com/22646/198505-22646646.pdf?src-supplier=Element14; ComponentLink1URL: https://datasheet.ciiva.com/22646/198505-22646646.pdf?src-supplier=Element14; ComponentLink2Description: https://datasheet.ciiva.com/1196/nte123ap-1196552.pdf?src-supplier=Element14; ComponentLink2URL: https://datasheet.ciiva.com/1196/nte123ap-1196552.pdf?src-supplier=Element14; Manufacturer: NTE Electronics; Manufacturer Part Number: 2N3904; Max Operating Temperature: 150°C; Min Operating Temperature: -55°C; Number of Pins: 3; Packaging: Bulk; Polarity: NPN; Power Dissipation: 625mW; Pricing 1: 1=0,52300, 10=0,30900, 25=0,24600, 50=0,18600, 100=0,15200, 500=0,12500 (USD); REACH SVHC: Unknown; RoHS: Yes; RoHS Compliant: Yes; Supplier 1: Newark; Supplier Part Number 1: 33C8259; Transition Frequency: 250MHz; Library Name: Extrusora_Schlib.SchLib
R1 R2 = CMP-009-00054-3: Axial Resistor, 100 KOhm, +/- 1%, 0.25 W; Manufacturer: Yageo; Power: 250mW; Voltage Rating: 250V; Case/Package: Axial; Component Kind: Standard; Datasheet URL: http://www.yageo.com/documents/recent/Yageo%20LR_MFR_2013.pdf; Datasheet Version: Apr-13; Manufacturer URL: http://www.yageo.com/; Max Operating Temperature: 155°C; Min Operating Temperature: -55°C; Mounting Technology: TH; Package Description: 2-Pin Axial Lead, Dia 2.4 mm, Body Length 6.3 mm; Package Quantity: 200; Package Reference: MFR-25; Packaging: Bulk; RoHS Compliant: True; Tolerance: 1%; Library Name: Universidade Estadual Paulista - Unesp
  R1: Value: 100k
  R2: Value: 47k
R3 R6-R12 R15-R18 = CMP-009-00054-3: Axial Resistor, 10 KOhm, +/- 1%, 0.25 W; Manufacturer: Yageo; Power: 250mW; Voltage Rating: 250V; Case/Package: Axial; Component Kind: Standard; Datasheet URL: http://www.yageo.com/documents/recent/Yageo%20LR_MFR_2013.pdf; Datasheet Version: Apr-13; Manufacturer URL: http://www.yageo.com/; Max Operating Temperature: 155°C; Min Operating Temperature: -55°C; Mounting Technology: TH; Package Description: 2-Pin Axial Lead, Dia 2.4 mm, Body Length 6.3 mm; Package Quantity: 200; Package Reference: MFR-25; Packaging: Bulk; RoHS Compliant: True; Tolerance: 1%; Library Name: Universidade Estadual Paulista - Unesp
  R3 R6-R12 R15-R17: Value: 10k
R4 R13 = CMP-009-00054-3: Axial Resistor, 100 Ohm, +/- 1%, 0.25 W; Manufacturer: Yageo; Power: 250mW; Voltage Rating: 250V; Case/Package: Axial; Component Kind: Standard; Datasheet URL: http://www.yageo.com/documents/recent/Yageo%20LR_MFR_2013.pdf; Datasheet Version: Apr-13; Manufacturer URL: http://www.yageo.com/; Max Operating Temperature: 155°C; Min Operating Temperature: -55°C; Mounting Technology: TH; Package Description: 2-Pin Axial Lead, Dia 2.4 mm, Body Length 6.3 mm; Package Quantity: 200; Package Reference: MFR-25; Packaging: Bulk; RoHS Compliant: True; Tolerance: 1%; Value: 100; Library Name: Universidade Estadual Paulista - Unesp
R5 = CMP-009-00054-3: Axial Resistor, 1 KOhm, +/- 1%, 0.25 W; Manufacturer: Yageo; Power: 250mW; Voltage Rating: 250V; Case/Package: Axial; Component Kind: Standard; Datasheet URL: http://www.yageo.com/documents/recent/Yageo%20LR_MFR_2013.pdf; Datasheet Version: Apr-13; Manufacturer URL: http://www.yageo.com/; Max Operating Temperature: 155°C; Min Operating Temperature: -55°C; Mounting Technology: TH; Package Description: 2-Pin Axial Lead, Dia 2.4 mm, Body Length 6.3 mm; Package Quantity: 200; Package Reference: MFR-25; Packaging: Bulk; RoHS Compliant: True; Tolerance: 1%; Value: 1k; Library Name: Universidade Estadual Paulista - Unesp
R14 = CMP-009-00054-3: Axial Resistor, 1 KOhm, +/- 1%, 0.25 W, -55 to 155 degC, 2-Pin THD, RoHS, Bulk; Manufacturer: Yageo; Power: 250mW; Voltage Rating: 250V; Case/Package: Axial; Component Kind: Standard; Datasheet URL: http://www.yageo.com/documents/recent/Yageo%20LR_MFR_2013.pdf; Datasheet Version: Apr-13; Manufacturer URL: http://www.yageo.com/; Max Operating Temperature: 155°C; Min Operating Temperature: -55°C; Mounting Technology: TH; Package Description: 2-Pin Axial Lead, Dia 2.4 mm, Body Length 6.3 mm; Package Quantity: 200; Package Reference: MFR-25; Packaging: Bulk; RoHS Compliant: True; Tolerance: 1%; Value: 1k; Library Name: Universidade Estadual Paulista - Unesp
U1 U2 = A4988_STEPPER_MOTOR_DRIVER_CARRIER: Stepper motor controler; IC: A4988; 1A; Uin mot: 8Ã·35V; MF: {'manufacturer': u'Pololu'}; Availability: Unavailable; Component Kind: Standard; MP: A4988_STEPPER_MOTOR_DRIVER_CARRIER; Package: None; Price: None; Library Name: Extrusora_Schlib.SchLib
U3-U8 = MAX6675: Módulo para termopar; Component Kind: Standard; Library Name: Extrusora_Schlib.SchLib
U9 = LM741: Single 44V 1MHz operational amplifier 8-PDIP 0 to 70; Manufacturer: TI National Semiconductor; Manufacturer Part Number: LM741CN/NOPB; Amplifier Type: GENERAL PURPOSE; Common Mode Rejection Ratio: 70dB; Gain: 96dB; Gain Bandwidth Product: 1.5MHz; Input Bias Current: 80nA; Input Offset Voltage (Vos): 2mV; Max Dual Supply Voltage: 18V; Max Power Dissipation: 500mW; Max Supply Current: 2.8mA; Max Supply Voltage: 36V; Min Dual Supply Voltage: 5V; Min Supply Voltage: 10V; Nominal Supply Current: 1.7mA; Number of Amplifiers: 1; Output Current: 25mA; Power Supply Rejection Ratio (PSRR): 77dB; Slew Rate: 0.5V/µs; Voltage Gain: 106.02dB; Bandwidth: 1MHz; Case/Package: DIP; Category: Amplifiers - Op Amps, Buffer, Instrumentation; Component Kind: Standard; ComponentLink1Description: https://datasheet.ciiva.com/26325/175935-da-01-en-ic-lm-741cn-nopb-dip8-tid-26325918.pdf?src-supplier=Conrad; ComponentLink1URL: https://datasheet.ciiva.com/26325/175935-da-01-en-ic-lm-741cn-nopb-dip8-tid-26325918.pdf?src-supplier=Conrad; ComponentLink2Description: https://datasheet.ciiva.com/26850/lm741cn-nopb-26850677.pdf?src-supplier=IIIC; ComponentLink2URL: https://datasheet.ciiva.com/26850/lm741cn-nopb-26850677.pdf?src-supplier=IIIC; ComponentLink3Description: https://datasheet.ciiva.com/14151/mflm741-data-e-14151370.pdf?src-supplier=Distrelec; ComponentLink3URL: https://datasheet.ciiva.com/14151/mflm741-data-e-14151370.pdf?src-supplier=Distrelec; ComponentLink4Description: https://datasheet.ciiva.com/8008/1862441-8008933.pdf?src-supplier=Element14; ComponentLink4URL: https://datasheet.ciiva.com/8008/1862441-8008933.pdf?src-supplier=Element14; Contact Plating: Tin,Matte; Height: 3.3mm; Lead Free: Lead Free; Length: 9.27mm; Max Operating Temperature: 70°C; Min Operating Temperature: 0°C; Mount: Through Hole; Number of Channels: 1; Number of Circuits: 1; Number of Elements: 1; Number of Pins: 8; Operating Supply Current: 1.7mA; Output Current per Channel: 25mA; Package Quantity: 40; Packaging: Rail/Tube; Power Dissipation: 500mW; Pricing 1: 1=0,91000, 10=0,81300, 25=0,77160, 100=0,63390, 250=0,59256, 500=0,52364, 1000=0,45500 (USD); Radiation Hardening: No; REACH SVHC: No SVHC; RoHS: Yes; RoHS Compliant: Yes; Stock 1: 18873; Supplier 1: Digi-Key; Supplier Part Number 1: LM741CNNS/NOPB-ND; Supply Current: 1.7mA; Technology: BIPOLAR; Voltage: 36V; Weight: 0.01lb; Width: 6.35mm; Library Name: Extrusora_Schlib.SchLib
U11 = LM7805CT: Series 3-Terminal Positive Regulators; Manufacturer: Texas Instruments; Manufacturer Part Number: LM7805CT/NOPB; Accuracy: 2%; Dropout Voltage: 2V; Max Input Voltage: 35V; Max Output Current: 1A; Min Input Voltage: 10V; Number of Outputs: 1; Output Type: Fixed; Quiescent Current: 8mA; Case/Package: TO-220; Category: Voltage Regulators - Linear; Component Kind: Standard; ComponentLink1Description: https://datasheet.ciiva.com/14280/lm340-n-14280911.pdf?src-supplier=Avnet; ComponentLink1URL: https://datasheet.ciiva.com/14280/lm340-n-14280911.pdf?src-supplier=Avnet; ComponentLink2Description: https://datasheet.ciiva.com/15083/lm7805c-15083790.pdf?src-supplier=Digi-Key; ComponentLink2URL: https://datasheet.ciiva.com/15083/lm7805c-15083790.pdf?src-supplier=Digi-Key; ComponentLink3Description: https://datasheet.ciiva.com/45987/902071-45987836.pdf?src-supplier=Jameco; ComponentLink3URL: https://datasheet.ciiva.com/45987/902071-45987836.pdf?src-supplier=Jameco; Height: 8.89mm; Lead Free: Contains Lead; Length: 10.16mm; Max Operating Temperature: 125°C; Min Operating Temperature: 0°C; Mount: Through Hole; Number of Pins: 3; Number of Regulators: 1; Output Current: 1A; Output Voltage Accuracy: 2%; Package Quantity: 45; Packaging: Rail/Tube; Polarity: Positive; Pricing 1: 1=1,63000, 10=1,46300, 25=1,38080, 100=1,17630, 250=1,10452, 500=0,96646, 1000=0,87007 (USD); Radiation Hardening: No; RoHS: Yes; RoHS Compliant: Yes; Series: -; Stock 1: 11933; Supplier 1: Digi-Key; Supplier Part Number 1: 296-47192-ND; Width: 4.7mm; Library Name: Extrusora_Schlib.SchLib
U12 = AMS1117 - 1.5V: Regulador de tensão ams1117; Component Kind: Standard; Library Name: Extrusora_Schlib.SchLib
VR1 = CMP-009-00039-1: Square Trimpot(R) Trimming Potentiometer, 10 KOhm, 300 V, -55 to 125 degC, 3-Pin THD, RoHS, Tube; Manufacturer: Bourns; Power: 500mW; Tolerance: 10%; Voltage Rating: 300V; Case/Package: 3326P; Component Kind: Standard; Composition: Potentiometer; Datasheet URL: http://www.bourns.com/docs/Product-Datasheets/3362.pdf; Datasheet Version: May-16; Lead Pitch: 2.54mm; Manufacturer URL: http://www.bourns.com/; Max Operating Temperature: 125°C; Min Operating Temperature: -55°C; Mounting Technology: TH; Package Description: 3-Pin Through Hole Device, Body 6.6 x 6.99 mm, Pitch 2.54 mm; Package Quantity: 250; Package Reference: 3326P; Packaging: Rail/Tube; Pins: 3; RoHS Compliant: True; Library Name: Universidade Estadual Paulista - Unesp
U10 = Shield Motor vnh2sp30 simples: Component Kind: Standard; Description: Shield Motor vnh2sp30 simples; Library Name: Extrusora_Schlib.SchLib
